# Altium schematic sheet AUX_Measure_Circuit.SchDoc(AUX_Measure_Circuit)
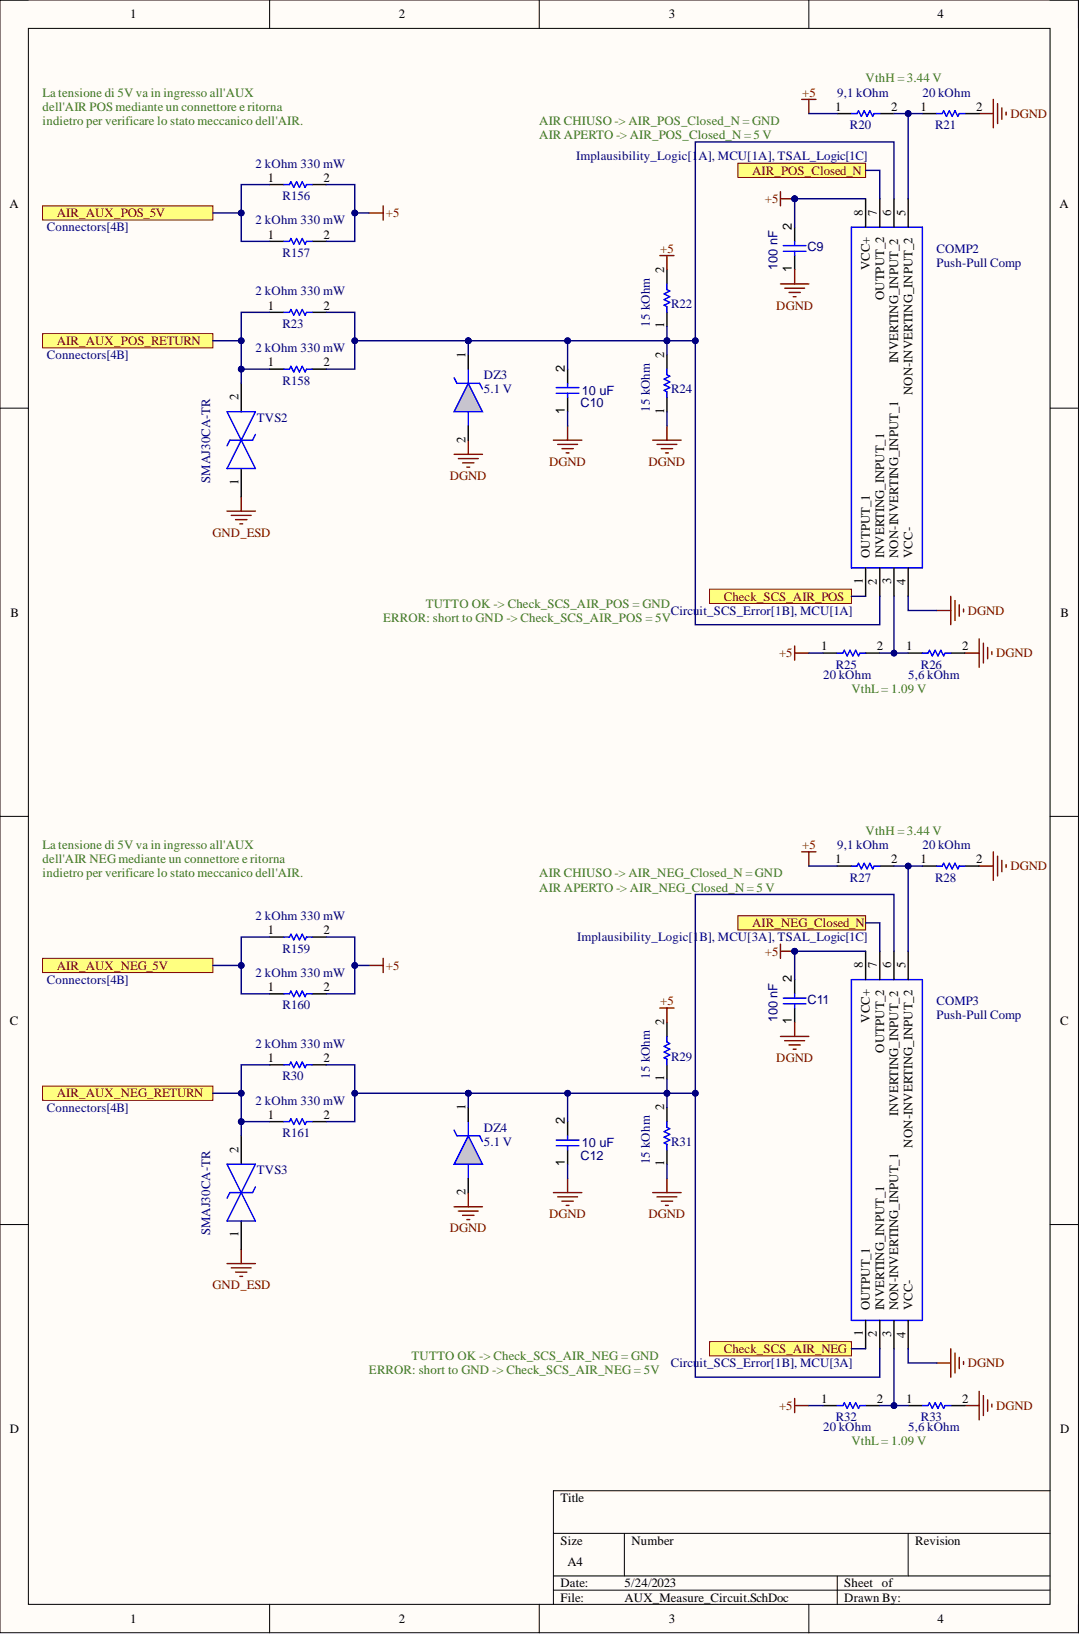
[diagram p0: AUX_Measure_Circuit.SchDoc]

## Parts
C9  100 nF
C10  10 uF
C11  100 nF
C12  10 uF
COMP2  Push-Pull Comp
COMP3  Push-Pull Comp
DZ3  5.1 V
DZ4  5.1 V
R20  9,1 kOhm
R21  20 kOhm
R22  15 kOhm
R23  2 kOhm 330 mW
R24  15 kOhm
R25  20 kOhm
R26  5,6 kOhm
R27  9,1 kOhm
R28  20 kOhm
R29  15 kOhm
R30  2 kOhm 330 mW
R31  15 kOhm
R32  20 kOhm
R33  5,6 kOhm
R156  2 kOhm 330 mW
R157  2 kOhm 330 mW
R158  2 kOhm 330 mW
R159  2 kOhm 330 mW
R160  2 kOhm 330 mW
R161  2 kOhm 330 mW
TVS2  SMAJ30CA-TR
TVS3  SMAJ30CA-TR

## Ports
AIR_AUX_NEG_5V, AIR_AUX_NEG_RETURN, AIR_AUX_POS_5V, AIR_AUX_POS_RETURN, AIR_NEG_Closed_N, AIR_POS_Closed_N, Check_SCS_AIR_NEG, Check_SCS_AIR_POS

## Nets
+5: C9.2 C11.2 COMP2.8(VCC+) COMP3.8(VCC+) R20.1 R22.2 R25.1 R27.1 R29.2 R32.1 R156.2 R157.2 R159.2 R160.2
DGND: C9.1 C10.1 C11.1 C12.1 COMP2.4(VCC-) COMP3.4(VCC-) DZ3.2 DZ4.2 R21.2 R24.1 R26.2 R28.2 R31.1 R33.2
GND_ESD: TVS2.1 TVS3.1
AIR_AUX_NEG_5V: R159.1 R160.1 ; port AIR_AUX_NEG_5V
AIR_AUX_NEG_RETURN: R30.1 R161.1 TVS3.2 ; port AIR_AUX_NEG_RETURN
AIR_AUX_POS_5V: R156.1 R157.1 ; port AIR_AUX_POS_5V
AIR_AUX_POS_RETURN: R23.1 R158.1 TVS2.2 ; port AIR_AUX_POS_RETURN
AIR_NEG_Closed_N: COMP3.7(OUTPUT_2) ; port AIR_NEG_Closed_N
AIR_POS_Closed_N: COMP2.7(OUTPUT_2) ; port AIR_POS_Closed_N
Check_SCS_AIR_NEG: COMP3.1(OUTPUT_1) ; port Check_SCS_AIR_NEG
Check_SCS_AIR_POS: COMP2.1(OUTPUT_1) ; port Check_SCS_AIR_POS
NetC10_2: C10.2 COMP2.2(INVERTING_INPUT_1) COMP2.6(INVERTING_INPUT_2) DZ3.1 R22.1 R23.2 R24.2 R158.2
NetC12_2: C12.2 COMP3.2(INVERTING_INPUT_1) COMP3.6(INVERTING_INPUT_2) DZ4.1 R29.1 R30.2 R31.2 R161.2
NetCOMP2_3: COMP2.3(NON-INVERTING_INPUT_1) R25.2 R26.1
NetCOMP2_5: COMP2.5(NON-INVERTING_INPUT_2) R20.2 R21.1
NetCOMP3_3: COMP3.3(NON-INVERTING_INPUT_1) R32.2 R33.1
NetCOMP3_5: COMP3.5(NON-INVERTING_INPUT_2) R27.2 R28.1

## Library data of the parts
C9 C11 = CMP-001-00085-2: VJ0805Y104MXATW1BC; Tech: SMD; Component Kind: Standard; ComponentLink1Description: Website; ComponentLink1URL: https://www.mouser.it/ProductDetail/KYOCERA-AVX/08055C104KAT4A?qs=2ujN4bDBNE8aFT7CFyBStg%3D%3D; Package: 0805; Library Name: EMEA- Squadra Corse PoliTO-  Politecnico di Torino
C10 C12 = CMP-001-00085-2: GRM21BR71A106KA73K; Tech: SMD; Component Kind: Standard; ComponentLink1Description: Website; ComponentLink1URL: https://www.mouser.it/ProductDetail/Vishay-Vitramon/VJ0805G106MXQTW1BC?qs=PqoDHHvF64%2FiIFVCZpIM5w%3D%3D; Package: 0805; Library Name: EMEA- Squadra Corse PoliTO-  Politecnico di Torino
COMP2 COMP3 = CMP-002-000018-1: TS3022IST; Manufacturer_Name: STMicroelectronics; Geometry.Height: 1.1mm; Tech: SMD; Component Kind: Standard; ComponentLink1Description: Website; ComponentLink1URL: https://www.mouser.it/ProductDetail/STMicroelectronics/TS3022IST?qs=2UmUEagh6Qpp25jOFnDwZw%3D%3D; Datasheet Link: https://www.st.com/resource/en/datasheet/ts3022.pdf; Design Item ID: TS3022IST; Manufacturer_Part_Number: TS3022IST; Mouser Part Number: 511-TS3022IST; Mouser Price/Stock: https://www.mouser.co.uk/ProductDetail/STMicroelectronics/TS3022IST?qs=2UmUEagh6Qpp25jOFnDwZw%3D%3D; Package: MiniSO-8; Source: TS3022IST.SchLib; Library Name: EMEA- Squadra Corse PoliTO-  Politecnico di Torino
DZ3 DZ4 = CMP-002-000008-1: MMSZ5231C-E3-08; Manufacturer_Name: Vishay; Geometry.Height: 1.35mm; Tech: SMD; Component Kind: Standard; ComponentLink1Description: Website; ComponentLink1URL: https://www.mouser.it/ProductDetail/Vishay-Semiconductors/MMSZ5231C-E3-08?qs=S660mHfF5o35EDHKMfkp8w%3D%3D; Datasheet Link: https://www.arrow.com/en/products/mmsz5231c-e3-08/vishay; Design Item ID: MMSZ5231C-E3-08; Manufacturer_Part_Number: MMSZ5231C-E3-08; Mouser Part Number: 78-MMSZ5231C-E3-08; Mouser Price/Stock: https://www.mouser.co.uk/ProductDetail/Vishay-Semiconductors/MMSZ5231C-E3-08?qs=S660mHfF5o35EDHKMfkp8w%3D%3D; Package: SOD-123-2; Source: MMSZ5231C-E3-08.SchLib; Library Name: EMEA- Squadra Corse PoliTO-  Politecnico di Torino
R20 R27 = CMP-009-00179-4: CRCW06039K10FKEA; Tech: SMD; Component Kind: Standard; ComponentLink1Description: Website; ComponentLink1URL: https://www.mouser.it/ProductDetail/Vishay-Dale/CRCW06039K10FKEA?qs=GPtCTTl25eGLvLFu2DRXcw%3D%3D; Package: 0603; Library Name: EMEA- Squadra Corse PoliTO-  Politecnico di Torino
R21 R25 R28 R32 = CMP-009-00179-4: CRCW060320K0FKEAC; Tech: SMD; Component Kind: Standard; ComponentLink1Description: Website; ComponentLink1URL: https://www.mouser.it/ProductDetail/Vishay-Dale/CRCW060320K0FKEB?qs=%252BZOPz%252B2NLYVQrVjDVZxTrA%3D%3D; Package: 0603; Library Name: EMEA- Squadra Corse PoliTO-  Politecnico di Torino
R22 R24 R29 R31 = CMP-009-00179-4: CRCW060315K0FKTA; Tech: SMD; Component Kind: Standard; ComponentLink1Description: Website; ComponentLink1URL: https://www.mouser.it/ProductDetail/Vishay-Dale/CRCW060315K0FKEA?qs=HpYJtD%2F%252BJmIvXVn2C2zFXQ%3D%3D; Package: 0603; Library Name: EMEA- Squadra Corse PoliTO-  Politecnico di Torino
R23 R30 R156-R161 = CMP-009-00179-4: CRCW06032K00FKEAHP; Tech: SMD; Component Kind: Standard; ComponentLink1Description: Website; ComponentLink1URL: https://www.mouser.it/ProductDetail/Vishay-Dale/CRCW06032K00FKEAHP?qs=k2%2FDWSARqgE7PHJQlU2Sxw%3D%3D; Package: 0603; Library Name: EMEA- Squadra Corse PoliTO-  Politecnico di Torino
R26 R33 = CMP-009-00179-4: CRCW06035K60FKEA; Tech: SMD; Component Kind: Standard; ComponentLink1Description: Website; ComponentLink1URL: https://www.mouser.it/ProductDetail/Vishay-Dale/CRCW06035K60FKEA?qs=%2FAtLfjUBFoX1vHGCl0oHag%3D%3D; Package: 0603; Library Name: EMEA- Squadra Corse PoliTO-  Politecnico di Torino
TVS2 TVS3 = CMP-004-000005-1: TVS Diode Bi-Directional SMAJ30CA-TR 64.3V; Manufacturer_Name: STMicroelectronics; Arrow Part Number: SMAJ30CA-TR; Arrow Price/Stock: https://www.arrow.com/en/products/smaj30ca-tr/stmicroelectronics?region=nac; Component Kind: Standard; Datasheet Link: https://www.st.com/resource/en/datasheet/smaj10ca.pdf; Design Item ID: SMAJ30CA-TR; Height: 2.65mm; Manufacturer_Part_Number: SMAJ30CA-TR; Mouser Part Number: 511-SMAJ30CA-TR; Mouser Price/Stock: https://www.mouser.co.uk/ProductDetail/STMicroelectronics/SMAJ30CA-TR?qs=0TJjK1waviDY0EkLaKH12g%3D%3D; Source: SamacSys.SchLib; Library Name: EMEA- Squadra Corse PoliTO-  Politecnico di Torino
